# Altium schematic sheet LED matrix.SchDoc
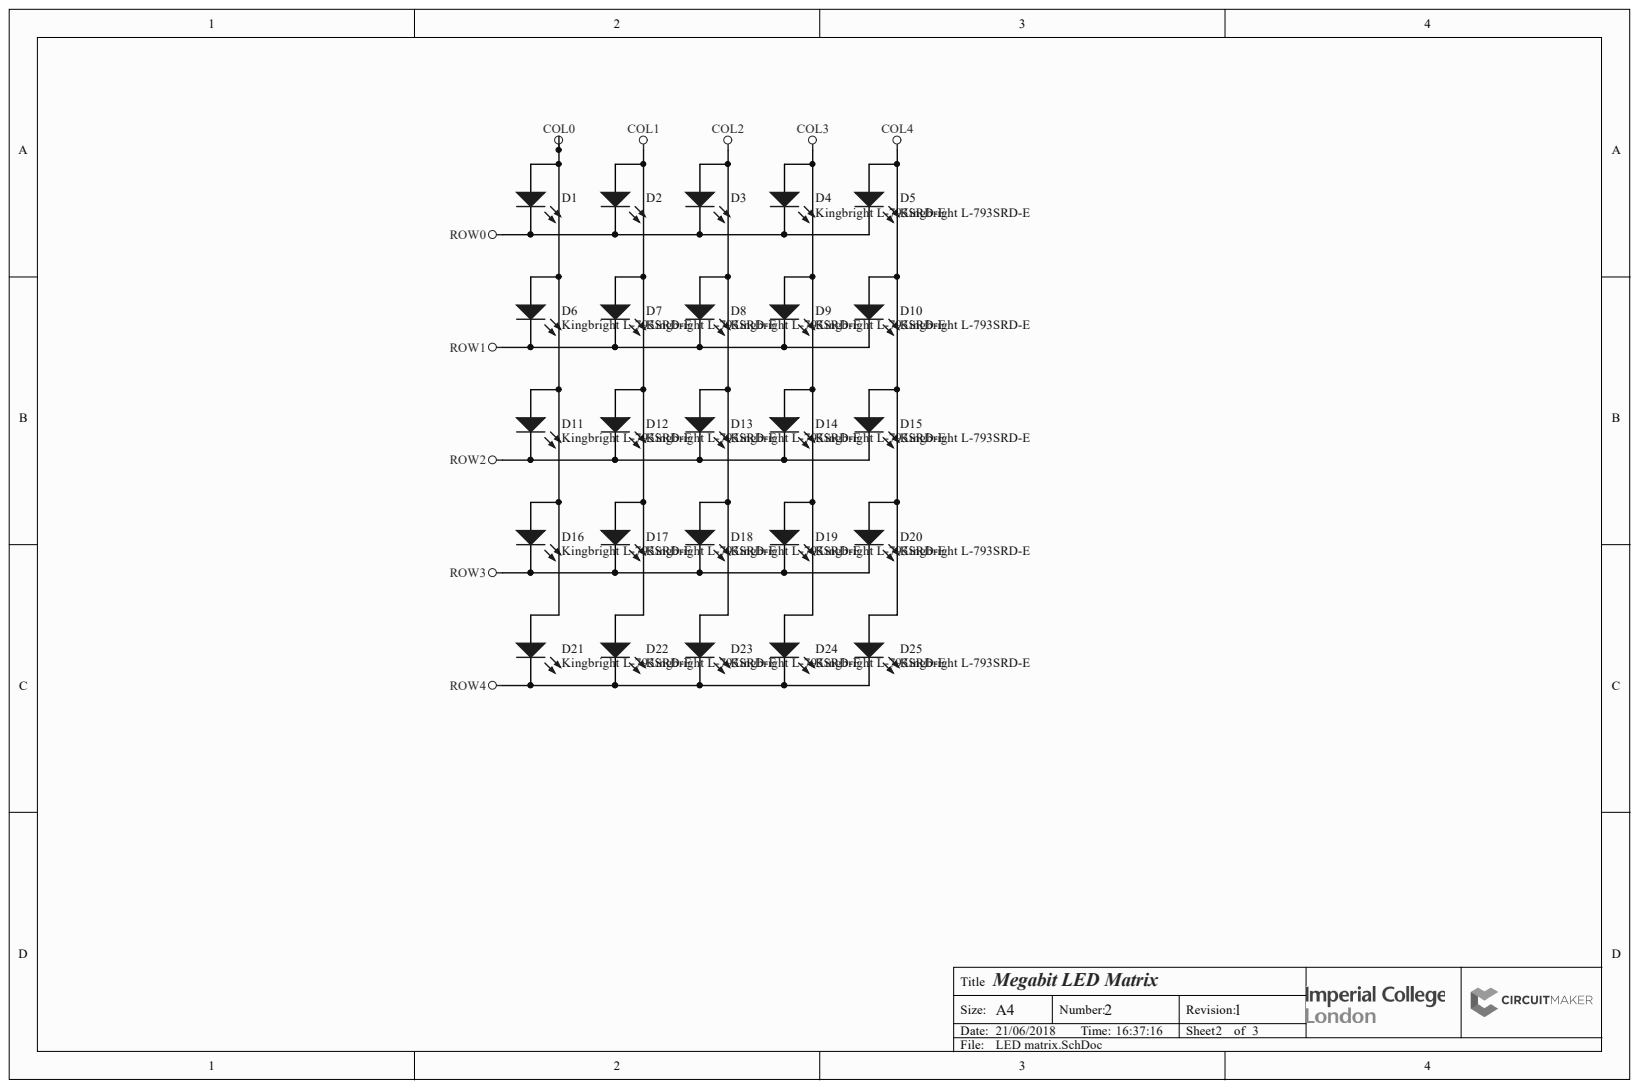
[diagram p0: LED matrix.SchDoc]

## Parts
D1  Visual Communications VAOL-10GAT4  [PCB-lyzc1mpxh94ommceivxw-1]  (value not drawn)
D2  Kingbright L-793SRD-E  [PCB-pjce6c538hbnjhyboevm-1]
D3  Kingbright L-793SRD-E  [PCB-pjce6c538hbnjhyboevm-1]
D4  Kingbright L-793SRD-E  [PCB-pjce6c538hbnjhyboevm-1]
D5  Kingbright L-793SRD-E  [PCB-pjce6c538hbnjhyboevm-1]
D6  Kingbright L-793SRD-E  [PCB-pjce6c538hbnjhyboevm-1]
D7  Kingbright L-793SRD-E  [PCB-pjce6c538hbnjhyboevm-1]
D8  Kingbright L-793SRD-E  [PCB-pjce6c538hbnjhyboevm-1]
D9  Kingbright L-793SRD-E  [PCB-pjce6c538hbnjhyboevm-1]
D10  Kingbright L-793SRD-E  [PCB-pjce6c538hbnjhyboevm-1]
D11  Kingbright L-793SRD-E  [PCB-pjce6c538hbnjhyboevm-1]
D12  Kingbright L-793SRD-E  [PCB-pjce6c538hbnjhyboevm-1]
D13  Kingbright L-793SRD-E  [PCB-pjce6c538hbnjhyboevm-1]
D14  Kingbright L-793SRD-E  [PCB-pjce6c538hbnjhyboevm-1]
D15  Kingbright L-793SRD-E  [PCB-pjce6c538hbnjhyboevm-1]
D16  Kingbright L-793SRD-E  [PCB-pjce6c538hbnjhyboevm-1]
D17  Kingbright L-793SRD-E  [PCB-pjce6c538hbnjhyboevm-1]
D18  Kingbright L-793SRD-E  [PCB-pjce6c538hbnjhyboevm-1]
D19  Kingbright L-793SRD-E  [PCB-pjce6c538hbnjhyboevm-1]
D20  Kingbright L-793SRD-E  [PCB-pjce6c538hbnjhyboevm-1]
D21  Kingbright L-793SRD-E  [PCB-pjce6c538hbnjhyboevm-1]
D22  Kingbright L-793SRD-E  [PCB-pjce6c538hbnjhyboevm-1]
D23  Kingbright L-793SRD-E  [PCB-pjce6c538hbnjhyboevm-1]
D24  Kingbright L-793SRD-E  [PCB-pjce6c538hbnjhyboevm-1]
D25  Kingbright L-793SRD-E  [PCB-pjce6c538hbnjhyboevm-1]

## Nets
COL0: D1.1 D6.1 D11.1 D16.1 D21.1
COL1: D2.1 D7.1 D12.1 D17.1 D22.1
COL2: D3.1 D8.1 D13.1 D18.1 D23.1
COL3: D4.1 D9.1 D14.1 D19.1 D24.1
COL4: D5.1 D10.1 D15.1 D20.1 D25.1
ROW0: D1.2 D2.2 D3.2 D4.2 D5.2
ROW1: D6.2 D7.2 D8.2 D9.2 D10.2
ROW2: D11.2 D12.2 D13.2 D14.2 D15.2
ROW3: D16.2 D17.2 D18.2 D19.2 D20.2
ROW4: D21.2 D22.2 D23.2 D24.2 D25.2

## Library data of the parts
D1 = CMP-95e05c75ddf64a27-1: Led, Red, t-3 (10mm), 480mcd, 640nm; Forward Voltage: 1.80 V; Viewing Angle: 30 °; Wavelength: 655 nm; Component Kind: Standard; Library Name: CommunityVault; Luminous Intensity: 480 mcd; Manufacturer Part Number: VAOL-10GAT4; Mounting Style: Through Hole; OctopartID: 95e05c75ddf64a27; Octopart URL: http://octopart.com/vaol-10gat4-visual+communications-10448240; RoHS: Compliant
D2-D25 = CMP-c7440e1cac271883-5: L-793SRD-E, Round Series Red LED, 640 nm 8 mm, Round Lens Through Hole package; Component Kind: Standard
  D2 D3 D6: Library Name: CommunityVault
  D4 D5 D7-D25: Library Name: FavoritesLibrary
